# Altium schematic sheet [7]-Interface_RS232.SchDoc
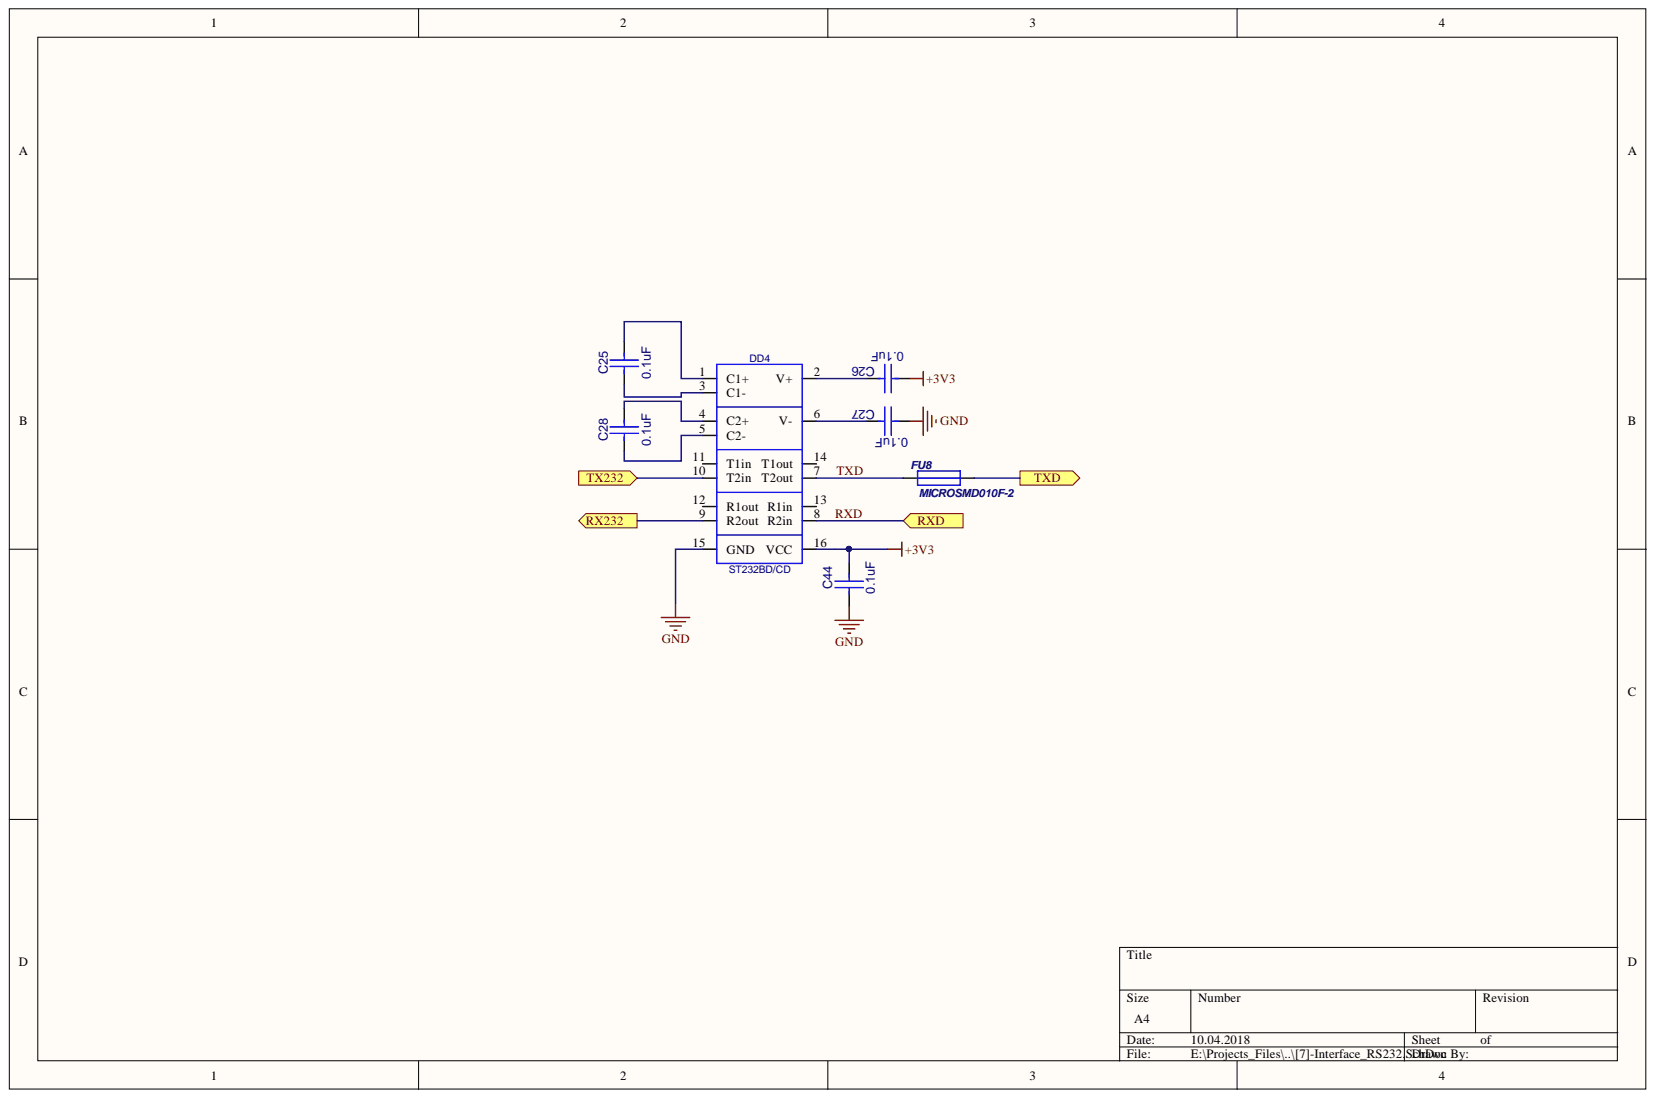
[diagram p0: [7]-Interface_RS232.SchDoc]

## Parts
C25  0.1uF  [CC0603]
C26  0.1uF  [CC0603]
C27  0.1uF  [CC0603]
C28  0.1uF  [CC0603]
C44  0.1uF  [CC0603]
DD4  ST232BD/CD  [SO16_M]
FU8  MICROSMD010F-2  [RC1210]

## Ports
RX232, RXD, TX232, TXD

## Nets
+3V3: C26.1 C44.1 DD4.16(VCC)
GND: C27.1 C44.2 DD4.15(GND)
RX232: DD4.9(R2out) ; port RX232
RXD: DD4.8(R2in) ; port RXD
TX232: DD4.10(T2in) ; port TX232
TXD: DD4.7(T2out) FU8.1
NetC25_1: C25.1 DD4.3(C1-)
NetC25_2: C25.2 DD4.1(C1+)
NetC26_2: C26.2 DD4.2(V+)
NetC27_2: C27.2 DD4.6(V-)
NetC28_1: C28.1 DD4.5(C2-)
NetC28_2: C28.2 DD4.4(C2+)
NetFU8_2: FU8.2 ; port TXD

Not connected: DD4 11(T1in) 12(R1out) 13(R1in) 14(T1out)

## Library data of the parts
C25-C28 C44 = CC0603: Component Kind: Standard; Description: CC0603; Value: 0.1uF; Library Name: gprs_modem_2.SchLib
FU8 = MICROSMD: Component Kind: Standard; Description: MICROSMD; Value: MICROSMD010F-2; Library Name: gprs_modem_2.SchLib
DD4 = ST232BD/CD: Component Kind: Standard; Description: ST232BD/CD; Library Name: gprs_modem_2.SchLib
